# Altium schematic sheet RS485.SchDoc(RS485)
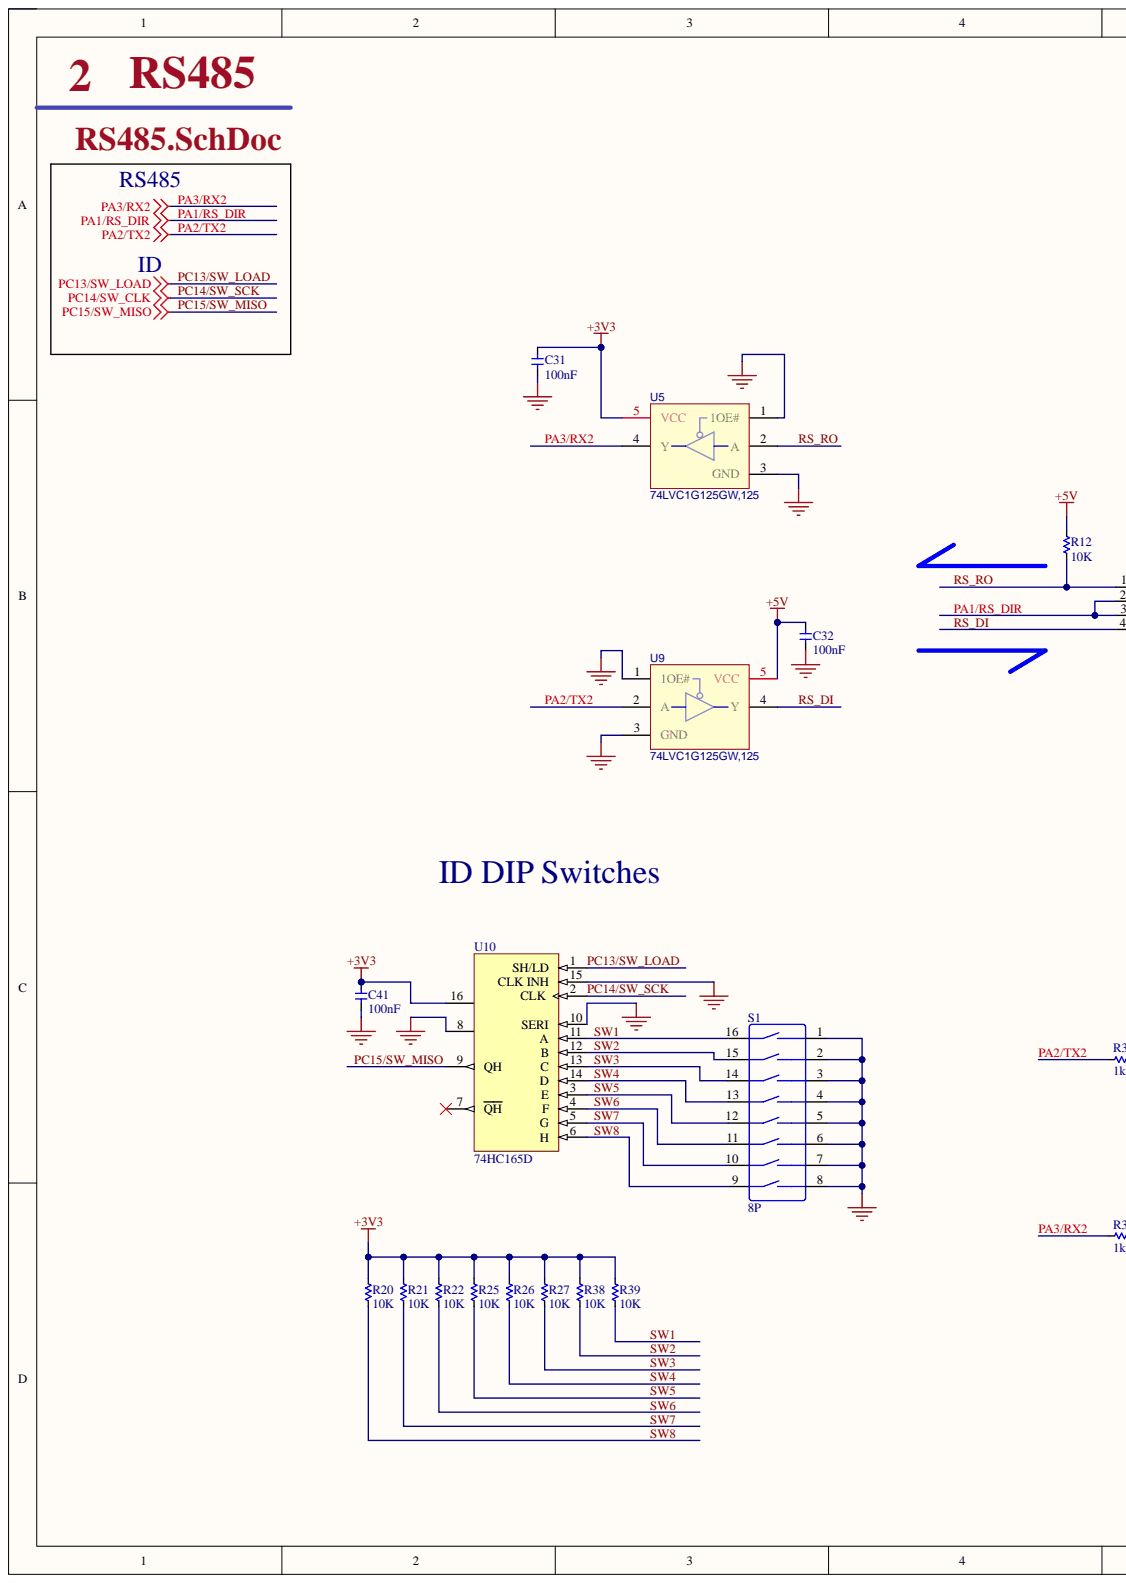
[diagram p0: RS485.SchDoc, left]
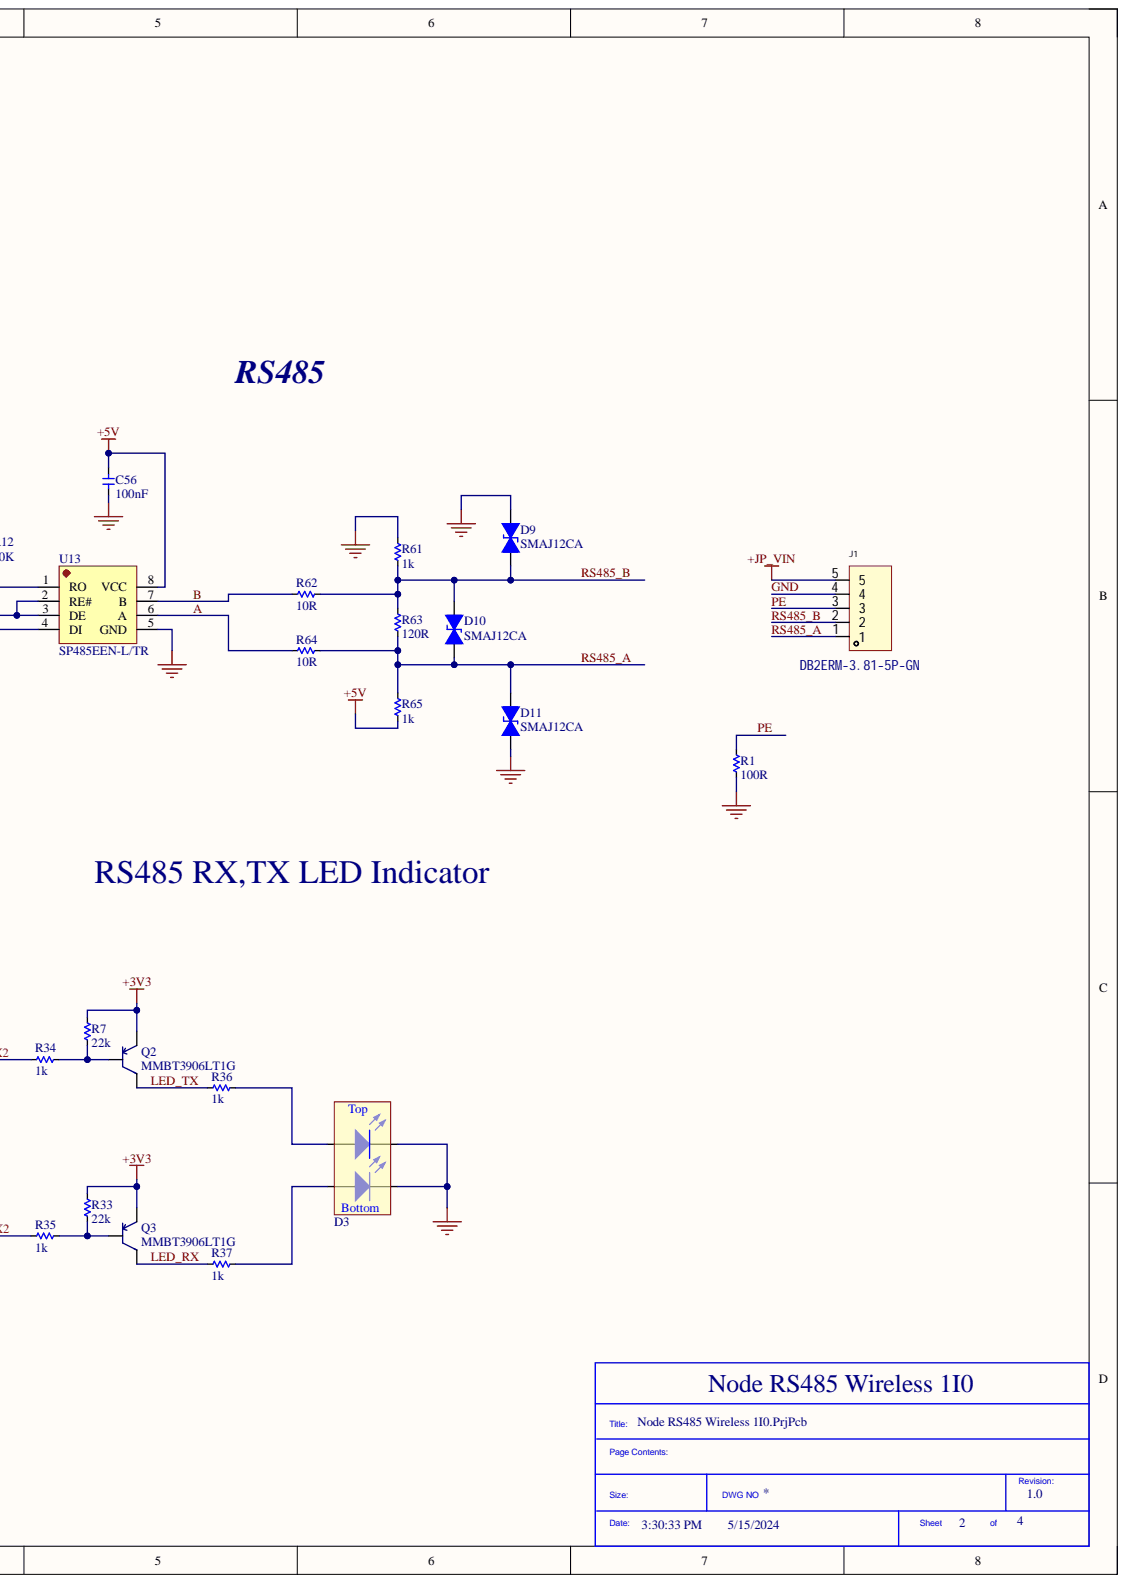
[diagram p1: RS485.SchDoc, right]

## Parts
C31  100nF  [C_0402]
C32  100nF  [C_0402]
C41  100nF  [C_0402]
C56  100nF  [C_0402]
D3  2LED_3MM  [2LED_3MM]  (value not drawn)
D9  SMAJ12CA  [SMA,DO-214AC - CA]
D10  SMAJ12CA  [SMA,DO-214AC - CA]
D11  SMAJ12CA  [SMA,DO-214AC - CA]
J1  DB2ERM-3.81-5P-GN  [CONN-TH_5P-P3.81_DB2ERM-3.81-5P]
Q2  MMBT3906LT1G  [SOT-23-3_L2.9-W1.6-P1.90-LS2.8-BR]
Q3  MMBT3906LT1G  [SOT-23-3_L2.9-W1.6-P1.90-LS2.8-BR]
R1  100R  [R_0805]
R7  22k  [R_0402]
R12  10K  [R_0402]
R20  10K  [R_0402]
R21  10K  [R_0402]
R22  10K  [R_0402]
R25  10K  [R_0402]
R26  10K  [R_0402]
R27  10K  [R_0402]
R33  22k  [R_0402]
R34  1k  [R_0402]
R35  1k  [R_0402]
R36  1k  [R_0402]
R37  1k  [R_0402]
R38  10K  [R_0402]
R39  10K  [R_0402]
R61  1k  [R_0402]
R62  10R  [R_0603]
R63  120R  [R_0603]
R64  10R  [R_0603]
R65  1k  [R_0402]
S1  8P  [SW-TH_KF1002-08P-R3GLG-DFT-02B]
U5  74LVC1G125GW,125  [SOT-353_L2.1-W1.3-P0.65-LS2.3-BR]
U9  74LVC1G125GW,125  [SOT-353_L2.1-W1.3-P0.65-LS2.3-BR]
U10  74HC165D  [SOIC-16_3.9x9.9x1.27P]
U13  SP485EEN-L/TR  [SOIC-8]

## Nets
+3V3: C31.2 C41.2 Q2.2 Q3.2 R7.1 R20.1 R21.1 R22.1 R25.1 R26.1 R27.1 R33.1 R38.1 R39.1 U5.5(VCC) U10.16(VCC)
+5V: C32.2 C56.2 R12.1 R65.2 U9.5(VCC) U13.8(VCC)
+JP_VIN: J1.5
A: R64.1 U13.6(A)
B: R62.1 U13.7(B)
GND: C31.1 C32.1 C41.1 C56.1 D3.2 D3.4 D9.1 D11.1 J1.4 R1.2 R61.1 S1.1 S1.2 S1.3 S1.4 S1.5 S1.6 S1.7 S1.8 U5.1(1OE#) U5.3(GND) U9.1(1OE#) U9.3(GND) U10.8(GND) U10.10(SERI) U10.15(CLK_INH) U13.5(GND)
LED_RX: Q3.3 R37.2
LED_TX: Q2.3 R36.2
PA1/RS_DIR: U13.2(RE#) U13.3(DE)
PA2/TX2: R34.2 U9.2(A)
PA3/RX2: R35.2 U5.4(Y)
PC13/SW_LOAD: U10.1(SH/LD)
PC14/SW_SCK: U10.2(CLK)
PC15/SW_MISO: U10.9(QH)
PE: J1.3 R1.1
RS485_A: D10.1 D11.2 J1.1 R63.1 R64.2 R65.1
RS485_B: D9.2 D10.2 J1.2 R61.2 R62.2 R63.2
RS_DI: U9.4(Y) U13.4(DI)
RS_RO: R12.2 U5.2(A) U13.1(RO)
SW1: R39.2 S1.16 U10.11(A)
SW2: R38.2 S1.15 U10.12(B)
SW3: R27.2 S1.14 U10.13(C)
SW4: R26.2 S1.13 U10.14(D)
SW5: R25.2 S1.12 U10.3(E)
SW6: R22.2 S1.11 U10.4(F)
SW7: R21.2 S1.10 U10.5(G)
SW8: R20.2 S1.9 U10.6(H)
NetD3_1: D3.1 R37.1
NetD3_3: D3.3 R36.1
NetQ2_1: Q2.1 R7.2 R34.1
NetQ3_1: Q3.1 R33.2 R35.1

Not connected: U10 7(QH)

## Library data of the parts
C31 C32 C41 C56 = 0402B104K160NT: 100nF 16V X7R ±10% 0402 Multilayer Ceramic Capacitors MLCC - SMD/SMT ROH; Manufacturer: FH(Guangdong Fenghua Advanced Tech); Assembly Type: SMT Assembly; Component Kind: Standard; ComponentLink1Description: Digikey Link; ComponentLink2Description: LCSC Link; ComponentLink2URL: https://jlcpcb.com/parts/componentSearch?searchTxt=10k%200603; LCSC Part Number: C41851; Library Name: Node RS485 Wireless 1I0.SCHLIB; Manufacturer Part Number: 0402B104K160NT; Package: 0402
D3 = 2LED3MM: LED DUAL RED/GREEN DIFFUSED T/H; Manufacturer: Lumex; Manufacturer Part Number: SSF-LXH240IGD; Assembly Type: Hand Soldering; Component Kind: Standard; ComponentLink1Description: https://datasheet.ciiva.com/16387/lumex20ssf-lxh240igd-16387412.pdf?src-supplier=Future+Electronics; ComponentLink1URL: https://datasheet.ciiva.com/16387/lumex20ssf-lxh240igd-16387412.pdf?src-supplier=Future+Electronics; ComponentLink2Description: https://datasheet.ciiva.com/4673/ssf-lxh240igd-59870-4673513.pdf?src-supplier=Mouser; ComponentLink2URL: https://datasheet.ciiva.com/4673/ssf-lxh240igd-59870-4673513.pdf?src-supplier=Mouser; ComponentLink3Description: https://datasheet.ciiva.com/14605/70127278-14605558.pdf?src-supplier=Allied+Electronics; ComponentLink3URL: https://datasheet.ciiva.com/14605/70127278-14605558.pdf?src-supplier=Allied+Electronics; ComponentLink4Description: https://datasheet.ciiva.com/16840/70127280-16840571.pdf?src-supplier=Allied+Electronics; ComponentLink4URL: https://datasheet.ciiva.com/16840/70127280-16840571.pdf?src-supplier=Allied+Electronics; ComponentLink5Description: https://datasheet.ciiva.com/1535/ssf-lxh240igd-1535964.pdf?src-supplier=Element14; ComponentLink5URL: https://datasheet.ciiva.com/1535/ssf-lxh240igd-1535964.pdf?src-supplier=Element14; ComponentLink6Description: https://datasheet.ciiva.com/1644/ssf-lxh240igd-1644716.pdf?src-supplier=Farnell; ComponentLink6URL: https://datasheet.ciiva.com/1644/ssf-lxh240igd-1644716.pdf?src-supplier=Farnell; ComponentLink7Description: https://datasheet.ciiva.com/1536/ssf-lxh240igd-1536046.pdf?src-supplier=Element14; ComponentLink7URL: https://datasheet.ciiva.com/1536/ssf-lxh240igd-1536046.pdf?src-supplier=Element14; ComponentLink8Description: https://datasheet.ciiva.com/1746/ssf-lxh240igd-1746846.pdf?src-supplier=Newark; ComponentLink8URL: https://datasheet.ciiva.com/1746/ssf-lxh240igd-1746846.pdf?src-supplier=Newark; ComponentLink9Description: http://datasheet.octopart.com/SSF-LXH240IGD-Lumex-datasheet-60839.pdf?src-supplier=Element14; ComponentLink9URL: http://datasheet.octopart.com/SSF-LXH240IGD-Lumex-datasheet-60839.pdf?src-supplier=Element14; ComponentLink10Description: http://datasheet.octopart.com/SSF-LXH240IGD-Lumex-datasheet-9743625.pdf?src-supplier=Allied+Electronics; ComponentLink10URL: http://datasheet.octopart.com/SSF-LXH240IGD-Lumex-datasheet-9743625.pdf?src-supplier=Allied+Electronics; LCSC Part Number: N/A; Library Name: Node RS485 Wireless 1I0.SCHLIB; Package: Through Hole
D9-D11 = SMAJ12CA: 1uA 20.1A 19.9V 13.3V 12V 15.3V Bi-Directional SMA(DO-214AC) TVS ROHS; Manufacturer: Yangzhou Yangjie Elec Tech; Assembly Type: SMT Assembly; Component Kind: Standard; LCSC Part Number: C698919; Library Name: Node RS485 Wireless 1I0.SCHLIB; Manufacturer Part Number: SMAJ12CA; Package: SMA(DO-214AC)
J1 = DB2ERM-3.81-5P-GN: x5P Green Board Edge/Receptacle-Close Screw fixed Push-Pull,P=3.81mm Pluggable System Terminal Block ROHS; Manufacturer: DORABO(地博电气); Add into BOM: yes; Connector Type: 板端/Receptacle-Close; Current Rating (Max): 10A; Number of PINs Per Row: 5; Pitch: 3.81mm; Structure: 1x5P; Voltage Rating (Max): 250V; Assembly Type: Extended Part; Color: Green; Component Kind: Standard; Convert to PCB: yes; Datasheet: https://atta.szlcsc.com/upload/public/pdf/source/20230327/78F62A8AA0F00A10D323B4F5A9B4658E.pdf; Device: DB2ERM-3.81-5P-GN; fixed form: Screw fixed; LCSC Part Name: 3.81mm 1x5P 排数:1 每排P数:5 螺丝固定; LCSC Part Number: C395702; Library Name: Node RS485 Wireless 1I0.SCHLIB; Manufacturer Part Number: DB2ERM-3.81-5P-GN; Mounting Style: Bend; Number of Pins: 5P; Number of Rows: 1; Operating Temperature Range: -40℃~+105℃; Package: SMD,P=3.81mm; Supplier: LCSC; Symbol: DB2ERM-3.81-5P
Q2 Q3 = MMBT3906LT1G: MMBT3906 TRANSISTORS (NPN/PNP) PNP 200MA 40V SOT-23(SOT-23-3) ROHS; Manufacturer: ON Semicon; Assembly Type: SMT Assembly; Component Kind: Standard; LCSC Part Number: C53444; Library Name: Node RS485 Wireless 1I0.SCHLIB; Manufacturer Part Number: MMBT3906LT1G; Package: SOT-23-3
R1 = 0805W8F1000T5E: 100OHMS ±1% 1/8W 0805 ROHS; Manufacturer: Uniroyal Elec; Assembly Type: SMT Assembly; Component Kind: Standard; LCSC Part Number: C17408; Library Name: Node RS485 Wireless 1I0.SCHLIB; Manufacturer Part Number: 0805W8F1000T5E; Package: 0805
R7 R33 = 0402WGF2202TCE: 22k 0402 62.5mW Thick Film Resistors 50V ±100ppm/℃ ±1% -55℃~+155℃ 22kΩ; Manufacturer: Uniroyal Elec; Assembly Type: SMT Assembly; Component Kind: Standard; LCSC Part Number: C25768; Library Name: Node RS485 Wireless 1I0.SCHLIB; Manufacturer Part Number: 0402WGF2202TCE; Package: 0402
R12 R20-R22 R25-R27 R38 R39 = 0402WGF1002TCE: 10kΩ 0402 62.5mW Thick Film Resistors 50V ±100ppm/℃ ±1% -55℃~+155℃; Manufacturer: Uniroyal Elec; Assembly Type: SMT Assembly; Component Kind: Standard; LCSC Part Number: C25744; Library Name: Node RS485 Wireless 1I0.SCHLIB; Manufacturer Part Number: 0402WGF1002TCE; Package: 0402
R34-R37 R61 R65 = 0402WGF1001TCE: 1kΩ 0402 62.5mW Thick Film Resistors 50V ±100ppm/℃ ±1% -55℃~+155℃; Manufacturer: Uniroyal Elec; Assembly Type: SMT Assembly; Component Kind: Standard; LCSC Part Number: C11702; Library Name: Node RS485 Wireless 1I0.SCHLIB; Manufacturer Part Number: 0402WGF1001TCE; Package: 0402
R62 R64 = 0603WAF100JT5E: 100mW Thick Film Resistors 75V ±1% ±400ppm/℃ -55℃~+155℃ 10Ω 0603 Chip Resistor - Surface Mount ROHS; Manufacturer: UNI-ROYAL(Uniroyal Elec); Assembly Type: SMT Assembly; Component Kind: Standard; LCSC Part Number: C22859; Library Name: Node RS485 Wireless 1I0.SCHLIB; Manufacturer Part Number: 0603WAF100JT5E; Package: 0603
R63 = 0603WAF1200T5E: 100mW Thick Film Resistors 75V ±100ppm/℃ ±1% -55℃~+155℃ 120Ω 0603 Chip Resistor - Surface Mount ROHS; Manufacturer: UNI-ROYAL(Uniroyal Elec); Assembly Type: SMT Assembly; Component Kind: Standard; LCSC Part Number: C22787; Library Name: Node RS485 Wireless 1I0.SCHLIB; Manufacturer Part Number: 0603WAF1200T5E; Package: 0603
S1 = KF1002-08P-R3GLG-DFT-02B: Plugin DIP Switches ROHS 8P; Manufacturer: KAIFENG; Assembly Type: Wave Soldering; Component Kind: Standard; LCSC Part Number: N/A; Library Name: Node RS485 Wireless 1I0.SCHLIB; Manufacturer Part Number: KF1002-08P-R3GLG-DFT-02B; Package: Plugin
U5 U9 = 74LVC1G125GW,125: 74LVC1G125GW,125 32mA 1.65V~5.5V 32mA SOT-353  Buffer/Driver/Transceiver ROHS; Manufacturer: Nexperia; Assembly Type: SMT Assembly; Component Kind: Standard; LCSC Part Number: C12519; Library Name: Node RS485 Wireless 1I0.SCHLIB; Manufacturer Part Number: 74LVC1G125GW,125; Package: SOT-353
U10 = 74HC165D,653: 74 SERIES SHIFT REGISTER 1 2V ~ 6V SOIC-16_150MIL ROHS; Manufacturer: Nexperia; Assembly Type: SMT Assembly; Component Kind: Standard; LCSC Part Number: C5613; Library Name: Node RS485 Wireless 1I0.SCHLIB; Manufacturer Part Number: 74HC165D,653; Package: SOIC-16_3.9x9.9x1.27P
U13 = SP485EEN-L/TR: transceiver 4.75V~5.25V 10Mbps 1/1 SOIC-8_150mil RS-485/RS-422 ICs ROHS; Manufacturer: MaxLinear; Assembly Type: SMT Assembly; Component Kind: Standard; LCSC Part Number: C6855; Library Name: Node RS485 Wireless 1I0.SCHLIB; Manufacturer Part Number: SP485EEN-L/TR; Package: SOIC-8
